# Altium schematic sheet FrontalLB50.SchDoc
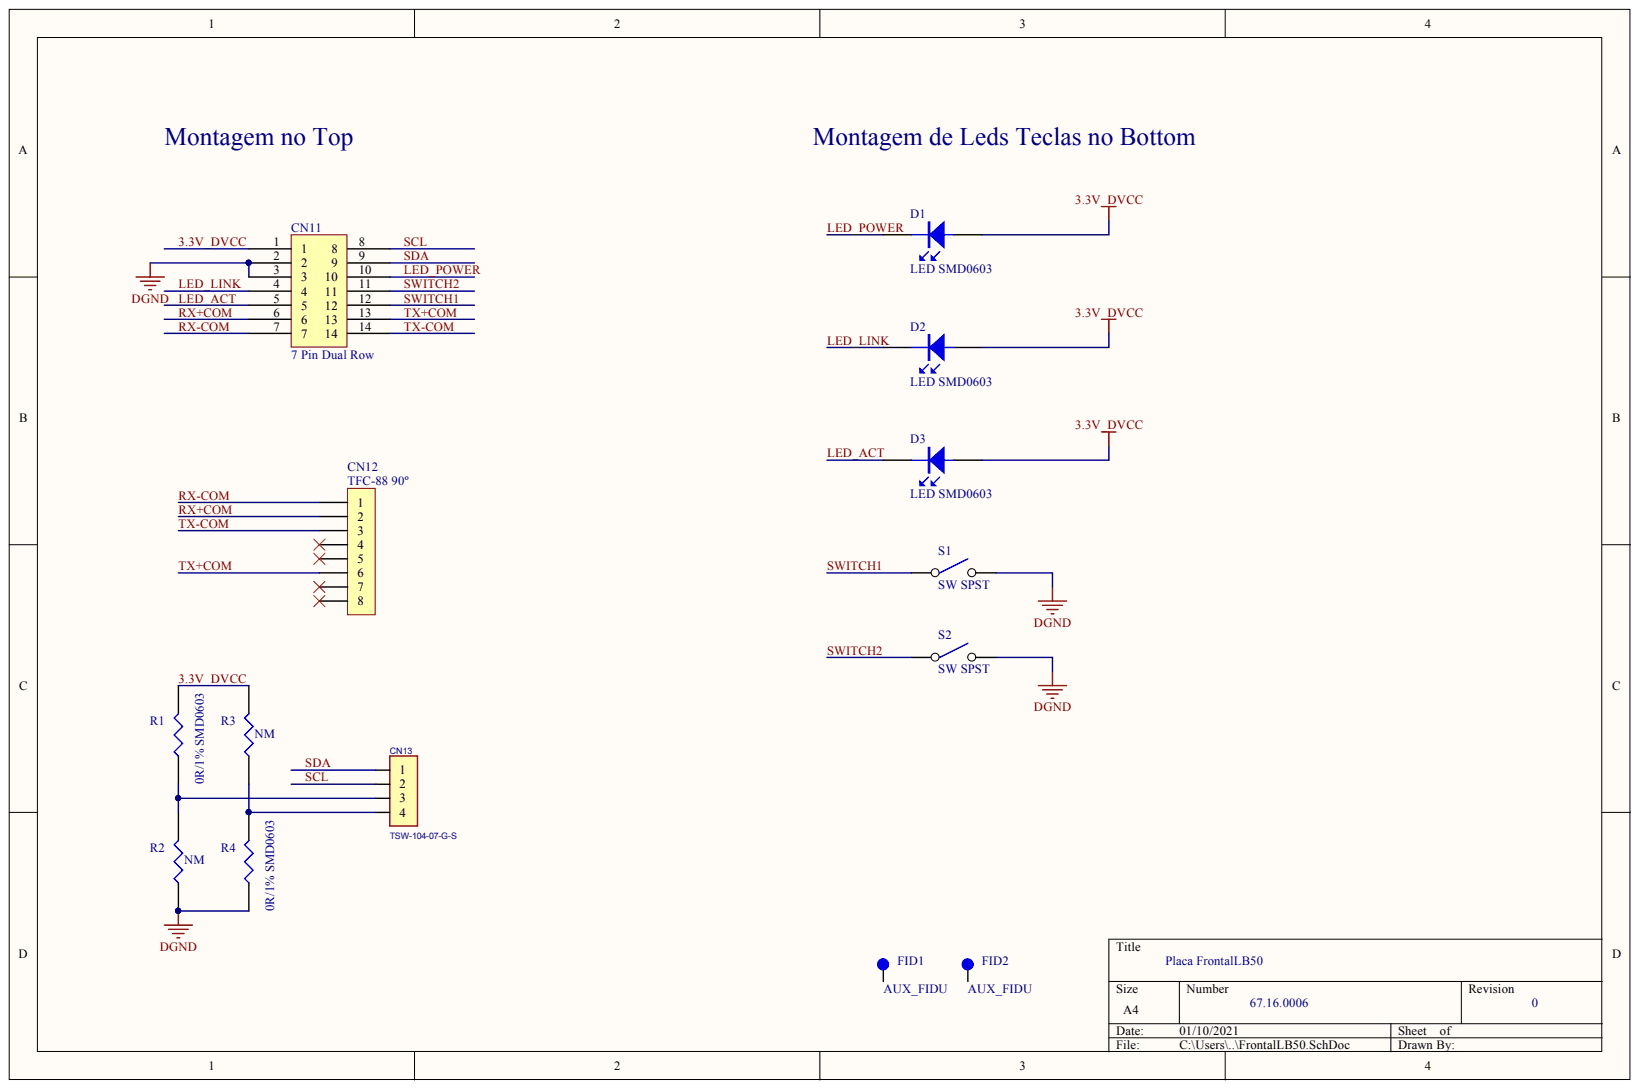
[diagram p0: FrontalLB50.SchDoc]

## Parts
CN11  7 Pin Dual Row
CN12  TFC-88 90º  [RJ45]
CN13  TSW-104-07-G-S  [DISPLAY_OLED_096_3027]
D1  LED SMD0603  [LED0603]
D2  LED SMD0603  [LED0603]
D3  LED SMD0603  [LED0603]
FID1  AUX_FIDU  [FIDUCIAL]
FID2  AUX_FIDU  [FIDUCIAL]
R1  0R/1% SMD0603  [0603]
R2  NM  [0603]
R3  NM  [0603]
R4  0R/1% SMD0603  [0603]
S1  SW SPST  [TACTOSMDA05S]
S2  SW SPST  [TACTOSMDA05S]

## Nets
DGND: CN11.2 CN11.3 R2.1 R4.1 S1.2 S2.2
3.3V_DVCC: CN11.1 D1.2 D2.2 D3.2 R1.2 R3.2
LED_ACT: CN11.5 D3.1
LED_LINK: CN11.4 D2.1
LED_POWER: CN11.10 D1.1
RX+COM: CN11.6 CN12.2
RX-COM: CN11.7 CN12.1
SCL: CN11.8 CN13.2
SDA: CN11.9 CN13.1
SWITCH1: CN11.12 S1.1
SWITCH2: CN11.11 S2.1
TX+COM: CN11.13 CN12.6
TX-COM: CN11.14 CN12.3
NetCN13_3: CN13.3 R1.1 R2.2
NetCN13_4: CN13.4 R3.1 R4.2

Not connected: CN12 4 5 7 8; FID1 1; FID2 1

## Library data of the parts
CN12 = CON8: Connector; Component Kind: Standard; Library Name: CP_MD30.IntLib
CN13 = CON4: Component Kind: Standard; Library Name: interface_v4.IntLib; PN: TSW-104-07-G-S
D1-D3 = LED: Component Kind: Standard; Library Name: CP_MD30.IntLib
FID1 FID2 = AUX_FIDU: FIDUCIAL; Component Kind: Standard; Library Name: AUXILIAR_SYM.LIB
R1-R4 = RES1: Component Kind: Standard; Library Name: CP_MD30.IntLib
CN11 = 7 Pin Dual Row: Component Kind: Standard; Library Name: SCH - CONNECTORS - 7 PIN DUAL ROW.SchLib
S1 S2 = SW SPST: Component Kind: Standard; Library Name: CP_MD30.IntLib
